# Altium schematic sheet USB.SchDoc
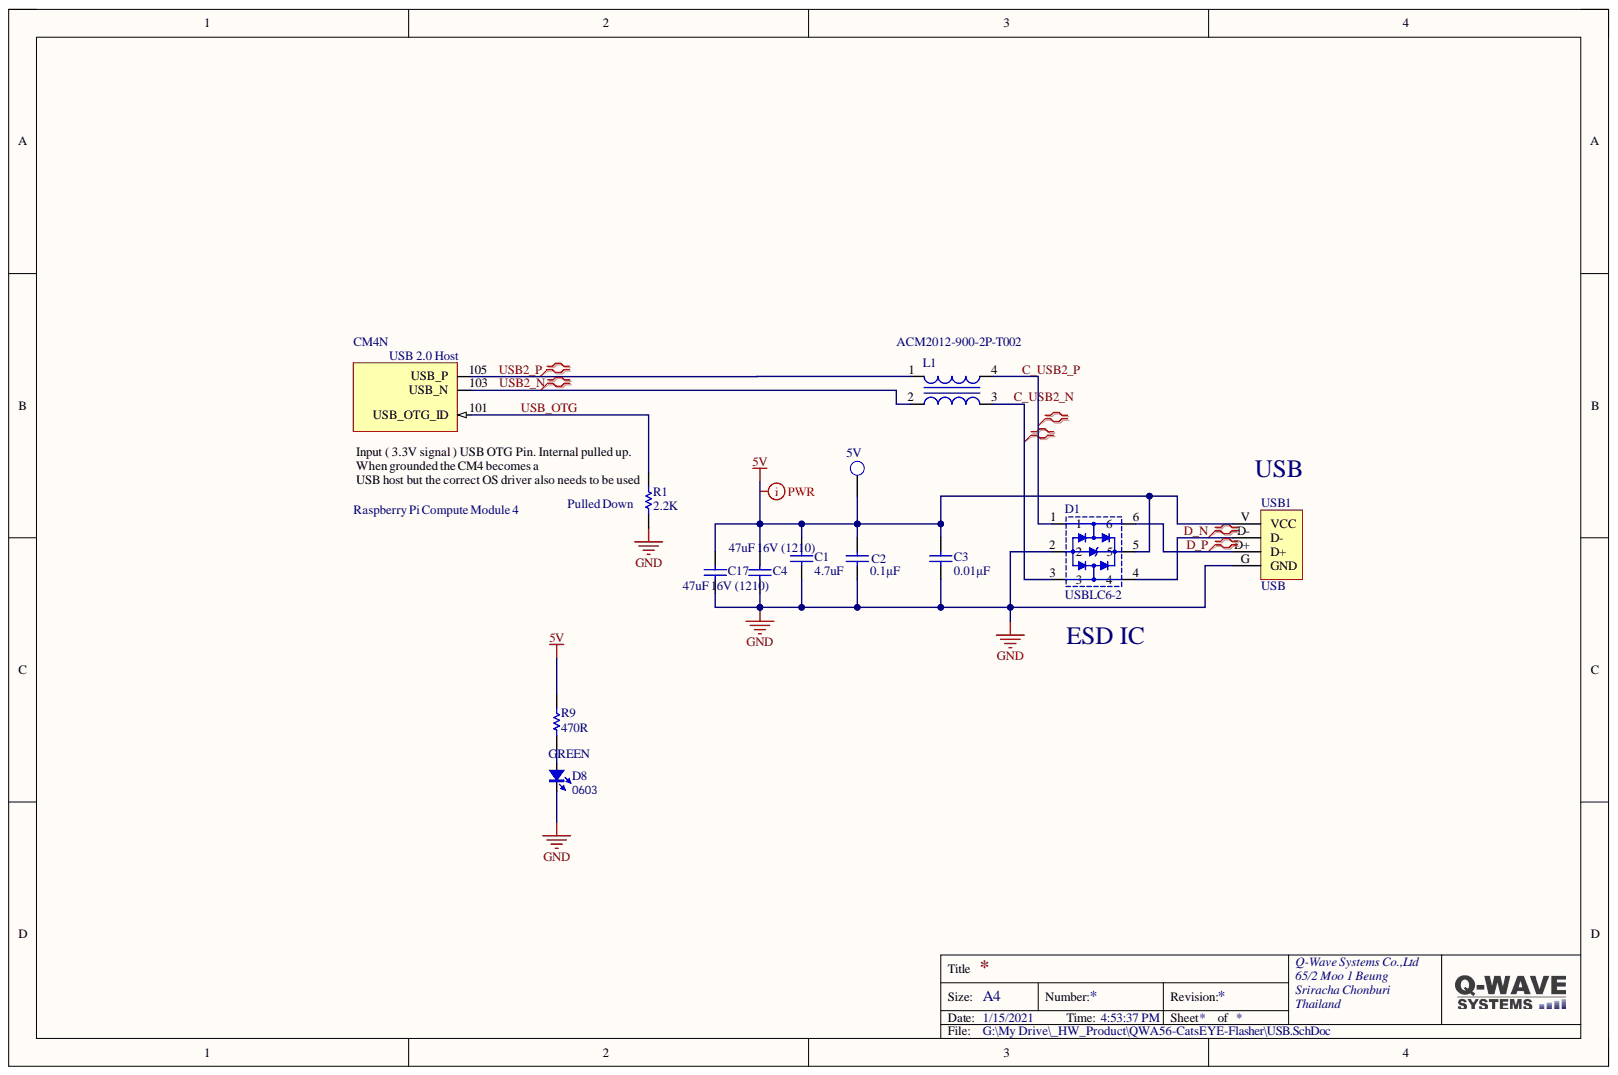
[diagram p0: USB.SchDoc]

## Parts
1  5V  [TEST_POINT]
C1  4.7uF  [CAP_0805]
C2  0.1µF  [CAP_0603]
C3  0.01µF  [CAP_0603]
C4  47uF 16V (1210)  [C 1210]
C17  47uF 16V (1210)  [C 1210]
CM4  Raspberry Pi Compute Module 4  [CM4-F40C-100DS-0.4v_1.5mm_0mm]  (part N)
D1  USBLC6-2  [SOT-666]
D8  GREEN  Type: 0603  [LED_0603_BLUE]
L1  ACM2012-900-2P-T002  [ACM2012]
R1  2.2K  [RES_0603]
R9  470R  [RES_0603]
USB1  USB  [USB_A/P_A]

## Nets
5V: 1.1 C1.1 C2.1 C3.2 C4.1 C17.1 D1.5 R9.2 USB1.V(VCC)
GND: C1.2 C2.2 C3.1 C4.2 C17.2 D1.2 D8.2 R1.2 USB1.G(GND)
C_USB2_N: D1.3 L1.3
C_USB2_P: D1.1 L1.4
D_N: D1.4 USB1.D-
D_P: D1.6 USB1.D+
USB2_N: CM4.103 L1.2
USB2_P: CM4.105 L1.1
USB_OTG: CM4.101 R1.1
NetD8_1: D8.1 R9.1

## Library data of the parts
1 = TEST_POINT: Test Point; Component Kind: Standard; Library Name: Misc.SCHLIB
C1 = Cap: 4.7µF ±10% 16V Ceramic Capacitor X5R 0805; MFG: KEMET; MFG P/N: C0603C475K8PACTU; Component Kind: Standard; Library Name: Miscellaneous Devices.IntLib; Signal Integrity: Cap; Simulation: CAP
C2 = Cap Semi: 0.1µF ±5% 50V Ceramic Capacitor X7R 0603; MFG: KEMET; MFG P/N: C0603C104J5RACTU; Component Kind: Standard; Library Name: Miscellaneous Devices.IntLib; Signal Integrity: Cap Semi; Simulation: CAP
C3 = Cap Semi: 0.01µF ±5% 50V Ceramic Capacitor X7R 0603; MFG: KEMET; MFG P/N: C0603C104J5RACTU; Component Kind: Standard; Library Name: Miscellaneous Devices.IntLib; Signal Integrity: Cap Semi; Simulation: CAP
C4 C17 = Cap: 47uF 16V Ceramic Capacitor X5R 1210; MFG: KEMET; MFG P/N: C0603C475K8PACTU; Component Kind: Standard; Library Name: Miscellaneous Devices.IntLib; Signal Integrity: Cap; Simulation: CAP
CM4 = CM4: Component Kind: Standard; Description: Raspberry Pi Compute Module 4; Library Name: CM4.SchLib
D1 = USBLC6-2: TVS DIODE 5.25V 17V SOT23-6; Component Kind: Standard; Library Name: x-io.SchLib
D8 = LED_0603: LED; Value: color?; Component Kind: Standard; Library Name: SCH_Active.SchLib
L1 = ACM2012-900-2P-T002: CHOKE COMM MODE 90 OHM .4A SMD; Manufacturer: TDK Corporation; .Checked PCB: RF; Current: 400mA; DC Resistance (DCR): 190 mOhm Max; Filter Type: Data, Signal Line; Impedance: 90 Ohm; Number of Lines: 2; Power (Watts): 0.063W, 1/16W; Resistance (Ohms): 8.2K; Temperature Coefficient: ฑ100ppm/ฐC; Tolerance: ฑ1%; Category: Filters; Component Kind: Standard; ComponentLink1Description: http://www.tdk.co.jp/tefe02/e9719_acm2012.pdf; ComponentLink1URL: http://www.tdk.co.jp/tefe02/e9719_acm2012.pdf; ComponentLink2Description: http://www.yageo.com/documents/recent/PYu-RC0402_51_RoHS_L_6.pdf; ComponentLink2URL: http://www.yageo.com/documents/recent/PYu-RC0402_51_RoHS_L_6.pdf; Composition: Thick Film; Features: -; Height: 0.016" (0.40mm); Height (Max): 0.051" (1.30mm); Inductance: -; Manufacturer Part Number: ACM2012-900-2P-T002; Mounting Type: Surface Mount; Number of Terminations: 2; Operating Temperature: -25ฐC ~ 85ฐC; Package / Case: 0805 (2012 Metric), 4 Lead; Packaging: Cut Tape (CT); Pricing 1: 1=0.48, 10=0.448, 50=0.42, 100=0.364, 500=0.308, 1000=0.252 (USD); RoHS: RoHS Compliant; Size / Dimension: 0.079" L x 0.047" W (2.00mm x 1.20mm); Stock 1: 175768; Supplier 1: Digi-Key; Supplier Part Number 1: 445-2207-1-ND; .Checked SCH: RF; Library Name: iMX6 Rex Development Baseboard V1I1.IntLib
R1 = Res Semi: Resistor 0603; Component Kind: Standard; Library Name: Miscellaneous Devices.IntLib; Signal Integrity: Res Semi; Simulation: RES
R9 = Res Semi: 470R Resistor 0603; Component Kind: Standard; Library Name: SCH_Passive.SchLib; Signal Integrity: Res Semi; Simulation: RES
USB1 = USB-A/P: Component Kind: Standard; Library Name: Connector USB AV.SchLib
